# Altium schematic sheet ESP32-HWE-Dev.SchDoc(ESP32-HWE-Dev)
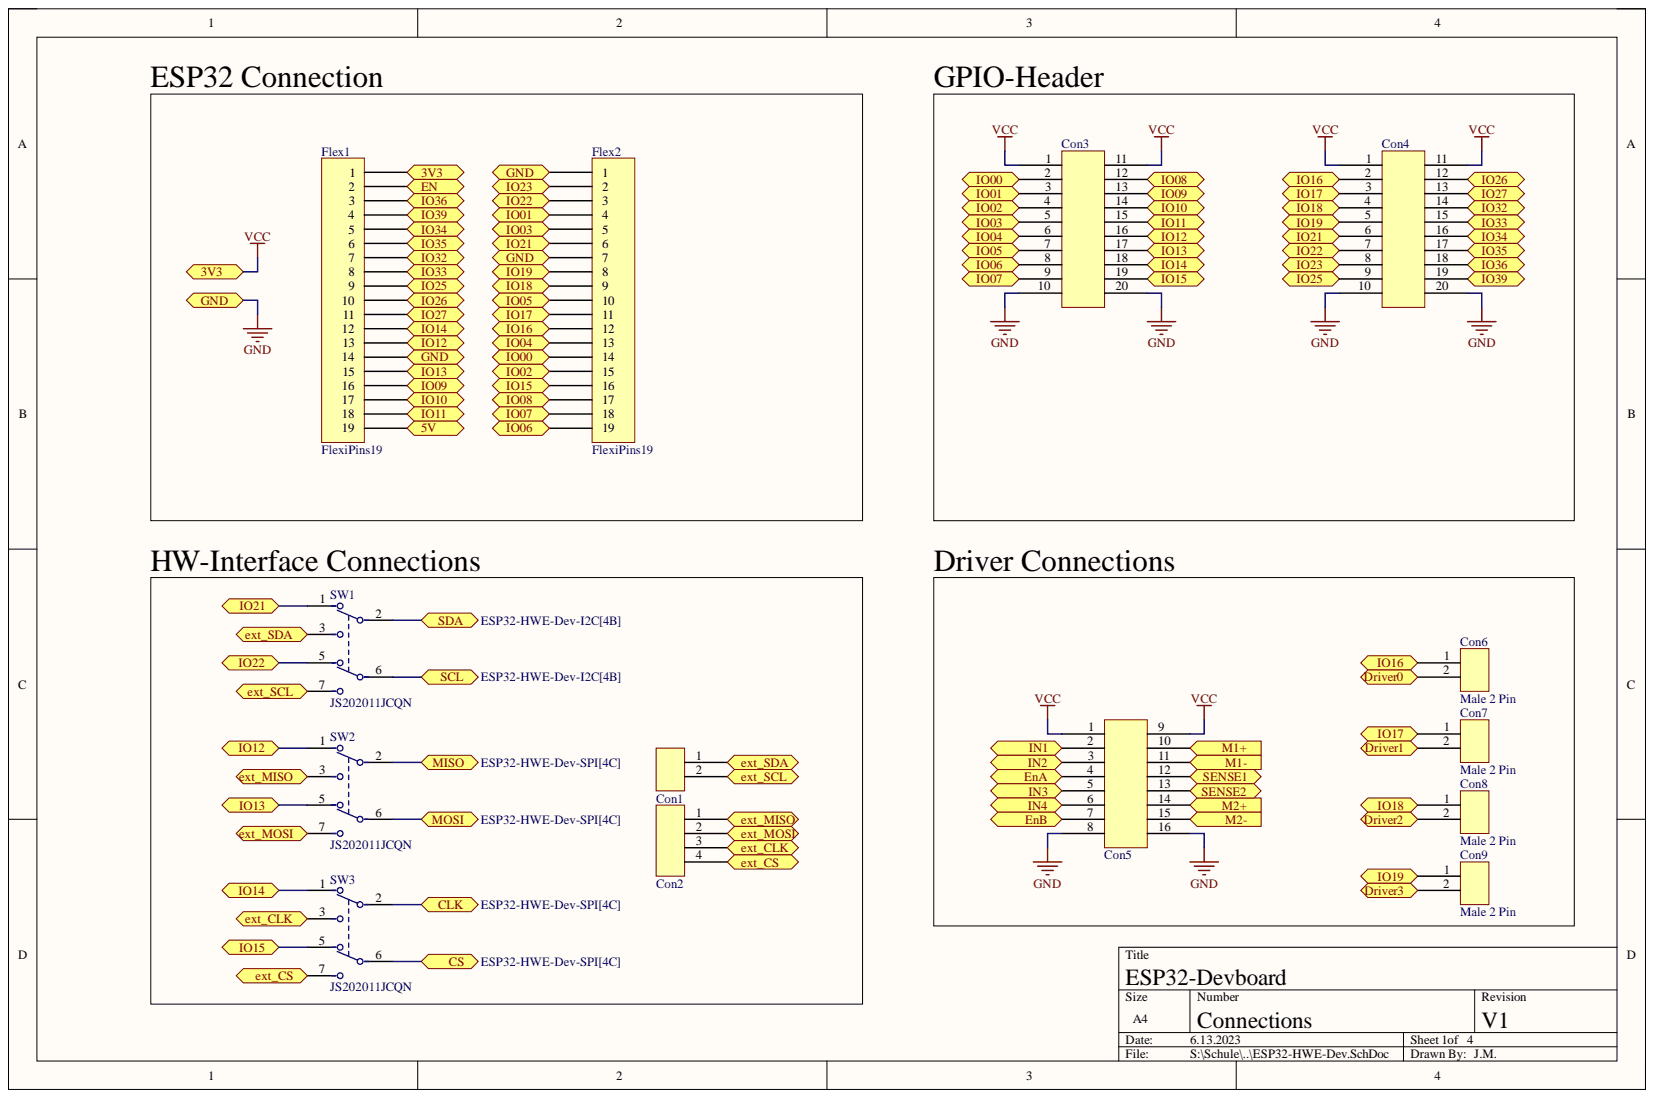
[diagram p0: ESP32-HWE-Dev.SchDoc]

## Parts
Con1  Female 2 Pin  [Header_Female_2Pin]  (value not drawn)
Con2  Female 4 Pin  [Header_Female_4Pin]  (value not drawn)
Con3  Female 2x10 Pin  [Header_Female_2x10Pin]  (value not drawn)
Con4  Female 2x10 Pin  [Header_Female_2x10Pin]  (value not drawn)
Con5  Female 2x8 Pin  [Header_Female_2x8Pin]  (value not drawn)
Con6  Male 2 Pin  [Header_Male_2Pin]
Con7  Male 2 Pin  [Header_Male_2Pin]
Con8  Male 2 Pin  [Header_Male_2Pin]
Con9  Male 2 Pin  [Header_Male_2Pin]
Flex1  FlexiPins19  [FlexiPins19]
Flex2  FlexiPins19  [FlexiPins19]
SW1  JS202011JCQN  [FP-JS202011JCQN-MFG]
SW2  JS202011JCQN  [FP-JS202011JCQN-MFG]
SW3  JS202011JCQN  [FP-JS202011JCQN-MFG]

## Ports
3V3, 5V, CLK, CS, Driver0, Driver1, Driver2, Driver3, EN, EnA, EnB, ext_CLK, ext_CS, ext_MISO, ext_MOSI, ext_SCL, ext_SDA, GND, IN1, IN2, IN3, IN4, IO00, IO01, IO02, IO03, IO04, IO05, IO06, IO07, IO08, IO09, IO10, IO11, IO12, IO13, IO14, IO15, IO16, IO17, IO18, IO19, IO21, IO22, IO23, IO25, IO26, IO27, IO32, IO33, IO34, IO35, IO36, IO39, M1+, M1-, M2+, M2-, MISO, MOSI, SCL, SDA, SENSE1, SENSE2

## Nets
5V: Flex1.19 ; port 5V
CLK: SW3.2 ; port CLK
CS: SW3.6 ; port CS
Driver0: Con6.2 ; port Driver0
Driver1: Con7.2 ; port Driver1
Driver2: Con8.2 ; port Driver2
Driver3: Con9.2 ; port Driver3
EN: Flex1.2 ; port EN
EnA: Con5.4 ; port EnA
EnB: Con5.7 ; port EnB
ext_CLK: Con2.3 SW3.3 ; port ext_CLK
ext_CS: Con2.4 SW3.7 ; port ext_CS
ext_MISO: Con2.1 SW2.3 ; port ext_MISO
ext_MOSI: Con2.2 SW2.7 ; port ext_MOSI
ext_SCL: Con1.2 SW1.7 ; port ext_SCL
ext_SDA: Con1.1 SW1.3 ; port ext_SDA
GND: Con3.10 Con3.20 Con4.10 Con4.20 Con5.8 Con5.16 Flex1.14 Flex2.1 Flex2.7 ; port GND
IN1: Con5.2 ; port IN1
IN2: Con5.3 ; port IN2
IN3: Con5.5 ; port IN3
IN4: Con5.6 ; port IN4
IO00: Con3.2 Flex2.14 ; port IO00
IO01: Con3.3 Flex2.4 ; port IO01
IO02: Con3.4 Flex2.15 ; port IO02
IO03: Con3.5 Flex2.5 ; port IO03
IO04: Con3.6 Flex2.13 ; port IO04
IO05: Con3.7 Flex2.10 ; port IO05
IO06: Con3.8 Flex2.19 ; port IO06
IO07: Con3.9 Flex2.18 ; port IO07
IO08: Con3.12 Flex2.17 ; port IO08
IO09: Con3.13 Flex1.16 ; port IO09
IO10: Con3.14 Flex1.17 ; port IO10
IO11: Con3.15 Flex1.18 ; port IO11
IO12: Con3.16 Flex1.13 SW2.1 ; port IO12
IO13: Con3.17 Flex1.15 SW2.5 ; port IO13
IO14: Con3.18 Flex1.12 SW3.1 ; port IO14
IO15: Con3.19 Flex2.16 SW3.5 ; port IO15
IO16: Con4.2 Con6.1 Flex2.12 ; port IO16
IO17: Con4.3 Con7.1 Flex2.11 ; port IO17
IO18: Con4.4 Con8.1 Flex2.9 ; port IO18
IO19: Con4.5 Con9.1 Flex2.8 ; port IO19
IO21: Con4.6 Flex2.6 SW1.1 ; port IO21
IO22: Con4.7 Flex2.3 SW1.5 ; port IO22
IO23: Con4.8 Flex2.2 ; port IO23
IO25: Con4.9 Flex1.9 ; port IO25
IO26: Con4.12 Flex1.10 ; port IO26
IO27: Con4.13 Flex1.11 ; port IO27
IO32: Con4.14 Flex1.7 ; port IO32
IO33: Con4.15 Flex1.8 ; port IO33
IO34: Con4.16 Flex1.5 ; port IO34
IO35: Con4.17 Flex1.6 ; port IO35
IO36: Con4.18 Flex1.3 ; port IO36
IO39: Con4.19 Flex1.4 ; port IO39
MISO: SW2.2 ; port MISO
MOSI: SW2.6 ; port MOSI
SCL: SW1.6 ; port SCL
SDA: SW1.2 ; port SDA
SENSE1: Con5.12 ; port SENSE1
SENSE2: Con5.13 ; port SENSE2
VCC: Con3.1 Con3.11 Con4.1 Con4.11 Con5.1 Con5.9 Flex1.1 ; port 3V3
NetCon5_10: Con5.10
NetCon5_11: Con5.11
NetCon5_14: Con5.14
NetCon5_15: Con5.15

## Library data of the parts
Con1 = Header_Female_2Pin: Component Kind: Standard; Library Name: ESP32-HWE-Dev.SchLib
Con2 = Header_Female_4Pin: Component Kind: Standard; Library Name: ESP32-HWE-Dev.SchLib
Con3 Con4 = Header_Female_2x10Pin: Component Kind: Standard; Library Name: ESP32-HWE-Dev.SchLib
Con5 = Header_Female_2x8Pin: Component Kind: Standard; Library Name: ESP32-HWE-Dev.SchLib
Con6-Con9 = Header_Male_2Pin: Component Kind: Standard; Library Name: ESP32-HWE-Dev.SchLib
SW1-SW3 = CMP-10038-000009-1: SWITCH SLIDE DPDT 300MA 6V; Actuator Length: 2mm; Actuator Material: Nylon; Actuator Type: Standard; Electrical Life: 5000Cycles; Switch Function: On-On; Component Kind: Standard; Contact Material: Copper Alloy; Contact Plating: Silver; Current Rating: 300mA; Library Name: Altium Content Vault; Max Operating Temperature: 85°C; Min Operating Temperature: -40°C; Mount: Surface Mount; Packaging: Cut Tape; Switch Travel: 2mm; Throw Configuration: DPDT; Voltage Rating (DC): 6V
Flex1 Flex2 = FlexiPins19: Component Kind: Standard; Library Name: ESP32-HWE-Uno.SchLib
